# Altium schematic sheet IO-Communite.SchDoc(IO-Communite)
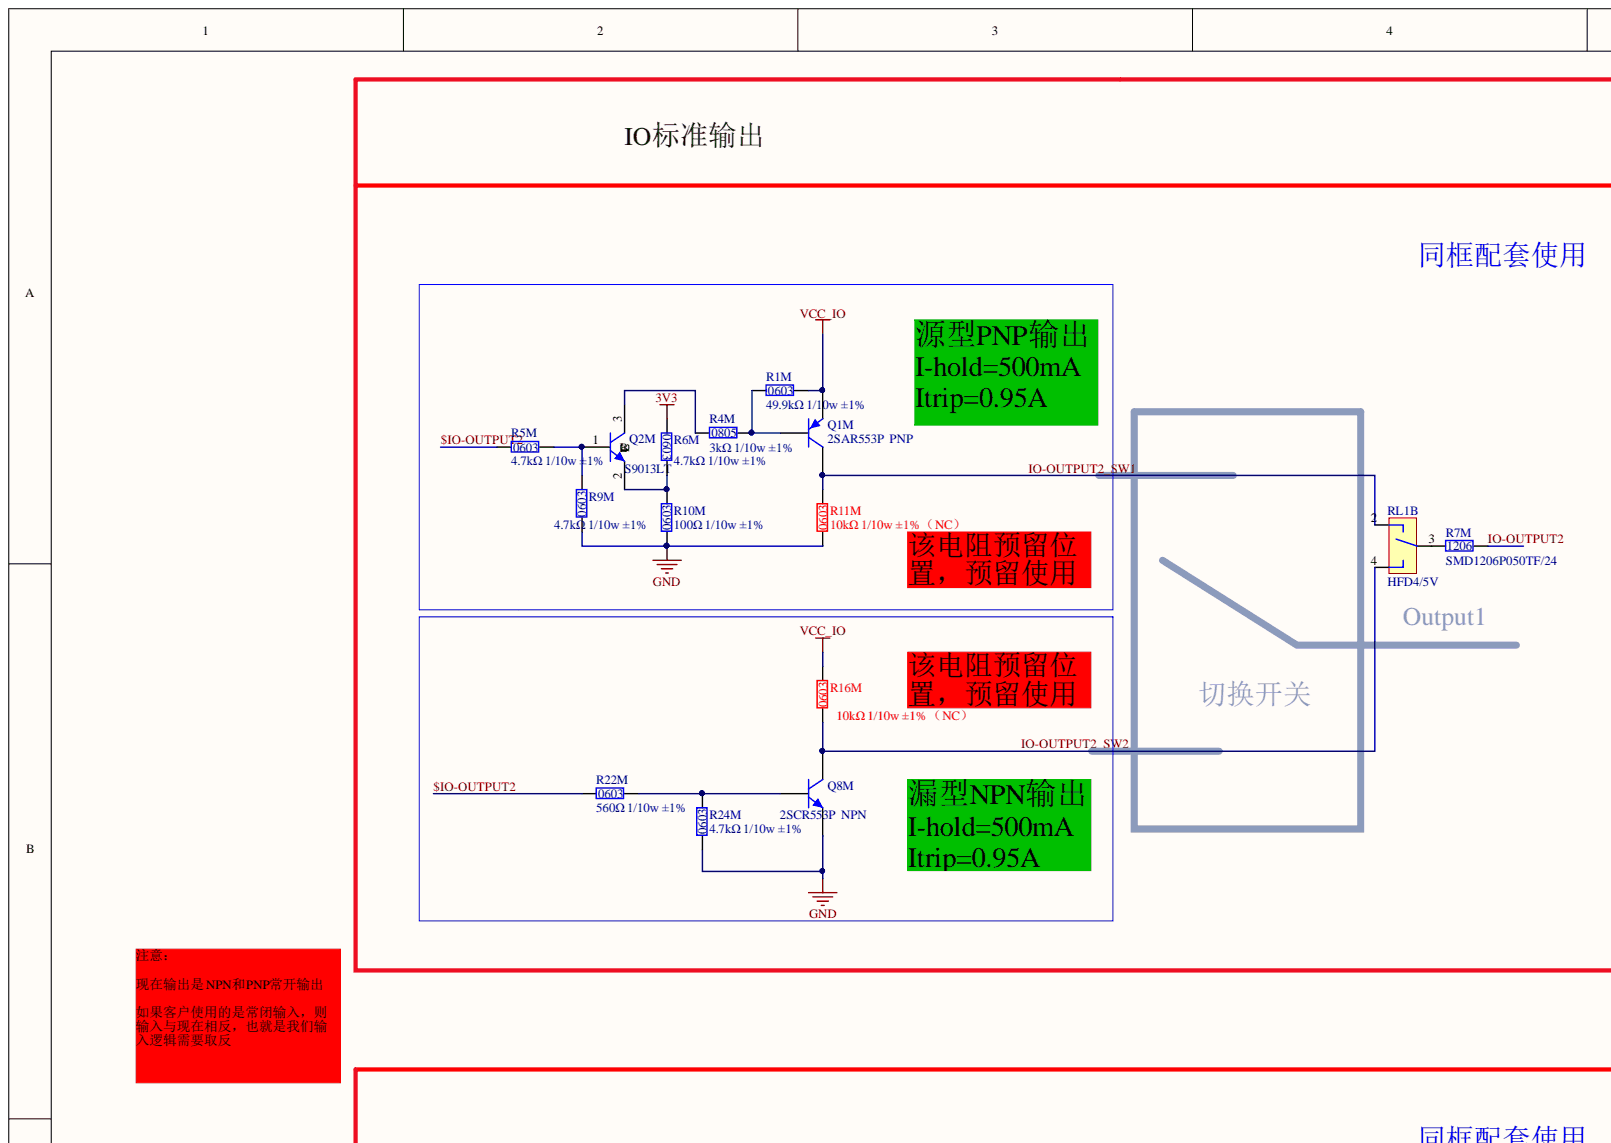
[diagram p0: IO-Communite.SchDoc, top left]
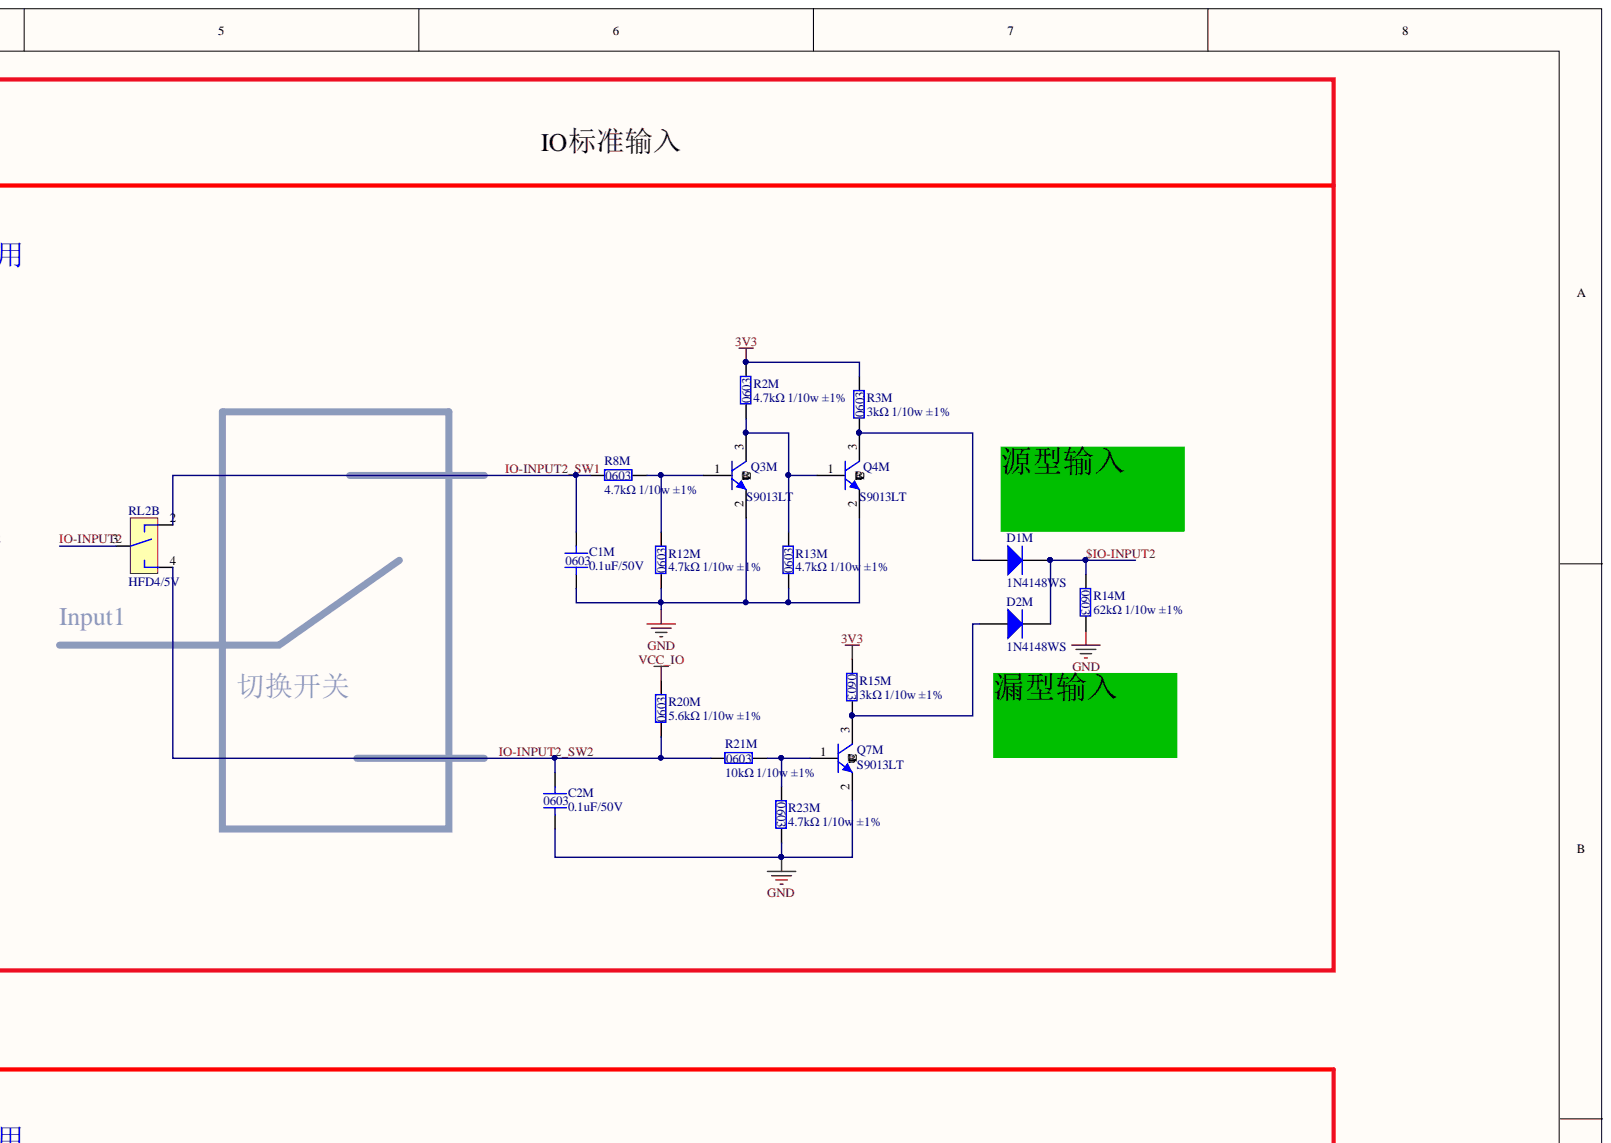
[diagram p1: IO-Communite.SchDoc, top right]
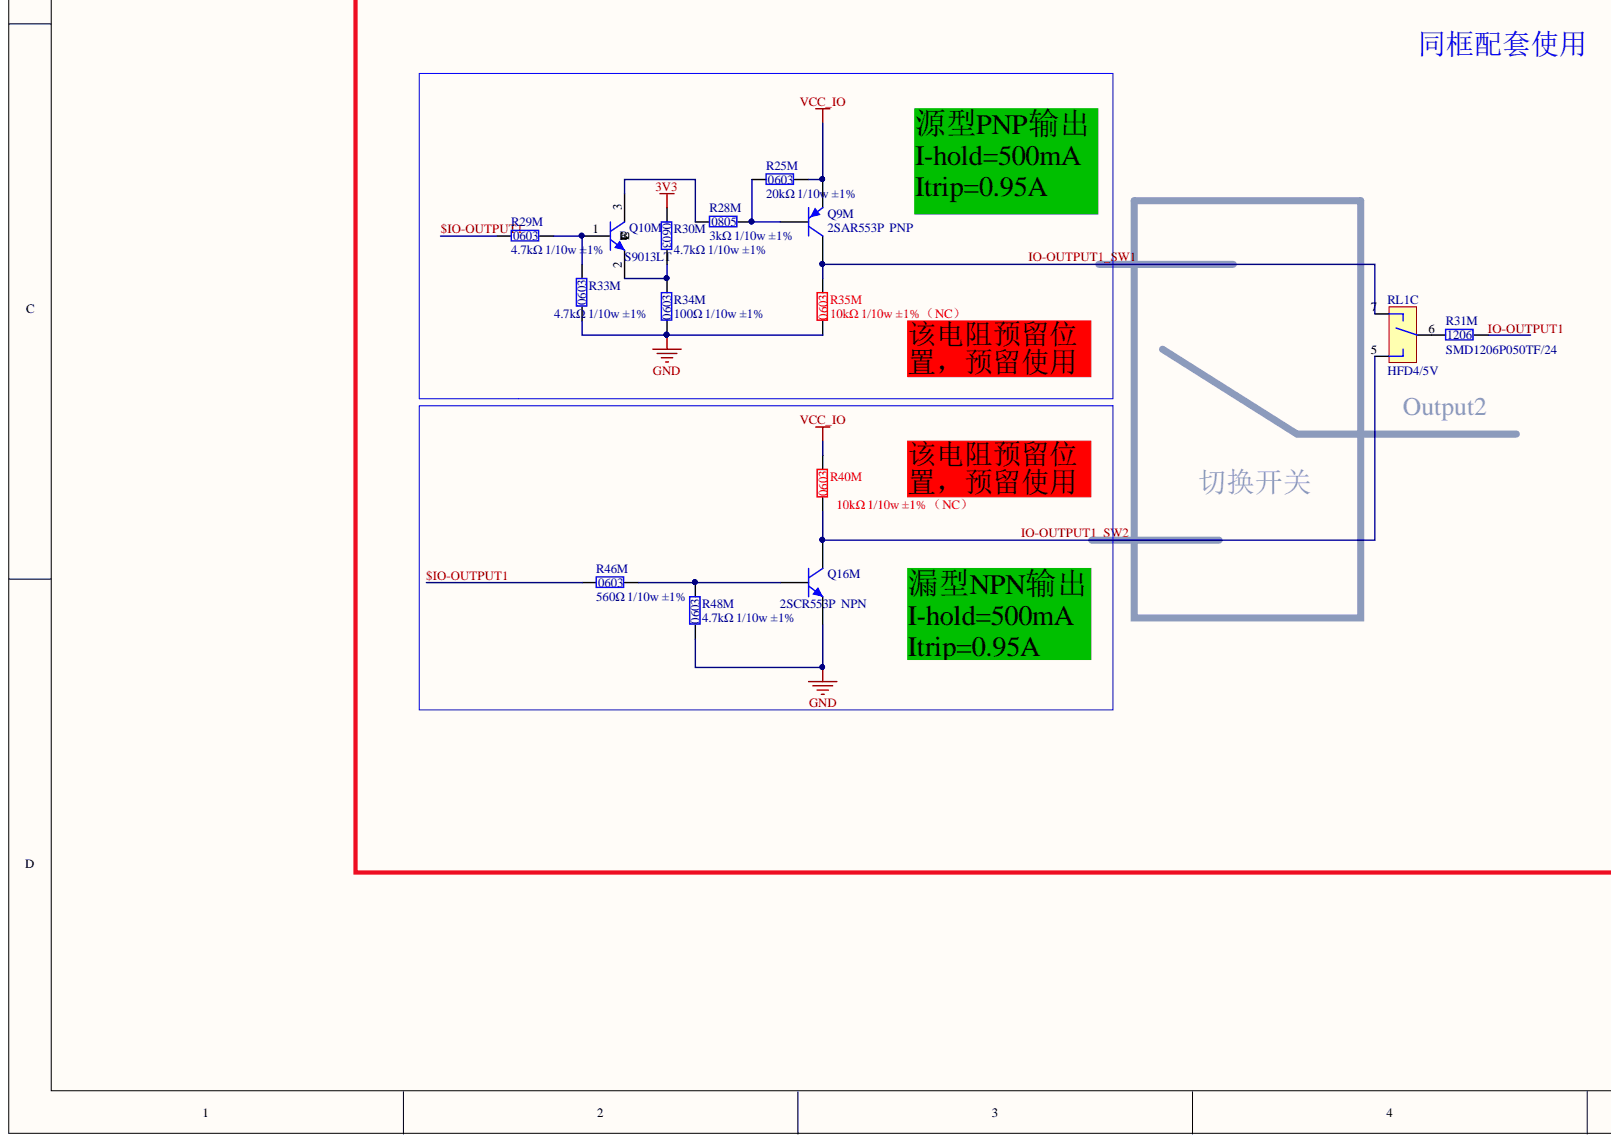
[diagram p2: IO-Communite.SchDoc, bottom left]
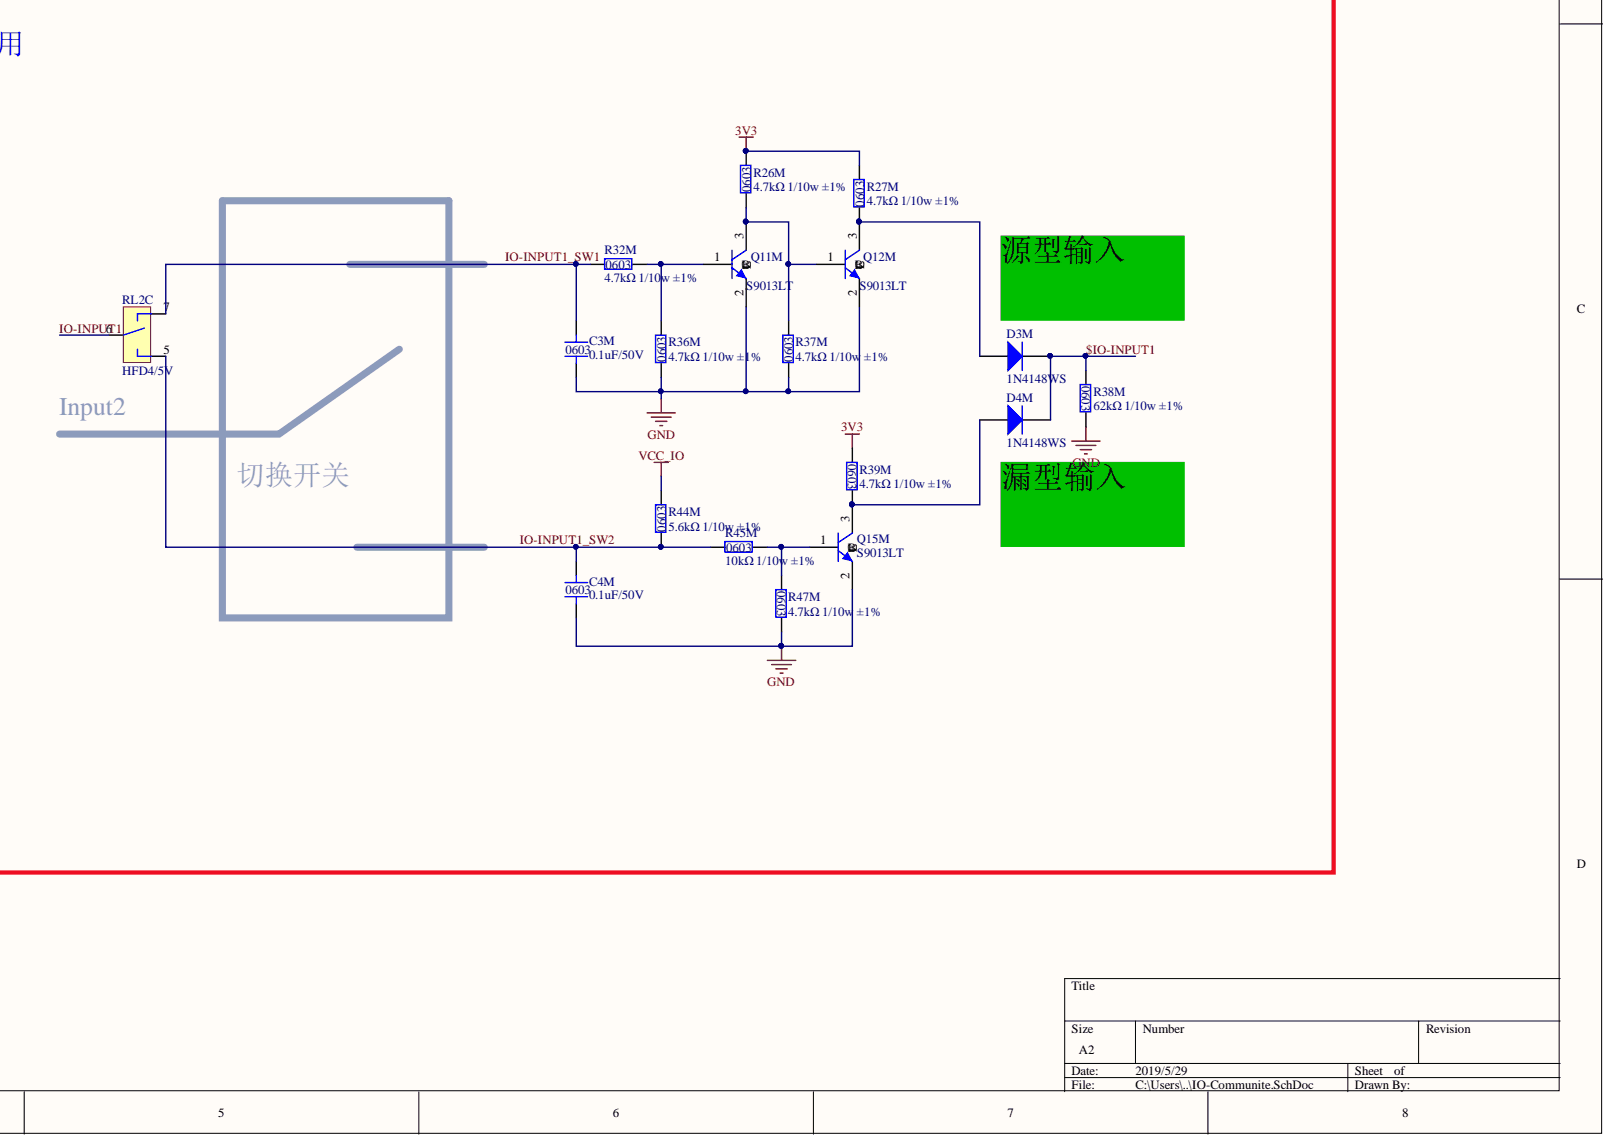
[diagram p3: IO-Communite.SchDoc, bottom right]

## Parts
C1M  0.1uF/50V  [C-0603]
C2M  0.1uF/50V  [C-0603]
C3M  0.1uF/50V  [C-0603]
C4M  0.1uF/50V  [C-0603]
D1M  1N4148WS  [SOD-323]
D2M  1N4148WS  [SOD-323]
D3M  1N4148WS  [SOD-323]
D4M  1N4148WS  [SOD-323]
Q1M  2SAR553P  PNP  [SOT-89]
Q2M  S9013LT  [SOT-23]
Q3M  S9013LT  [SOT-23]
Q4M  S9013LT  [SOT-23]
Q7M  S9013LT  [SOT-23]
Q8M  2SCR553P  NPN  [SOT-89]
Q9M  2SAR553P  PNP  [SOT-89]
Q10M  S9013LT  [SOT-23]
Q11M  S9013LT  [SOT-23]
Q12M  S9013LT  [SOT-23]
Q15M  S9013LT  [SOT-23]
Q16M  2SCR553P  NPN  [SOT-89]
R1M  49.9kΩ 1/10w ±1%  [R-0603]
R2M  4.7kΩ 1/10w ±1%  [R-0603]
R3M  3kΩ 1/10w ±1%  [R-0603]
R4M  3kΩ 1/10w ±1%  [R-0805]
R5M  4.7kΩ 1/10w ±1%  [R-0603]
R6M  4.7kΩ 1/10w ±1%  [R-0603]
R7M  SMD1206P050TF/24  [R-1206]
R8M  4.7kΩ 1/10w ±1%  [R-0603]
R9M  4.7kΩ 1/10w ±1%  [R-0603]
R10M  100Ω 1/10w ±1%  [R-0603]
R11M  10kΩ 1/10w ±1%（NC）  [R-0603]
R12M  4.7kΩ 1/10w ±1%  [R-0603]
R13M  4.7kΩ 1/10w ±1%  [R-0603]
R14M  62kΩ 1/10w ±1%  [R-0603]
R15M  3kΩ 1/10w ±1%  [R-0603]
R16M  10kΩ 1/10w ±1%（NC）  [R-0603]
R20M  5.6kΩ 1/10w ±1%  [R-0603]
R21M  10kΩ 1/10w ±1%  [R-0603]
R22M  560Ω 1/10w ±1%  [R-0603]
R23M  4.7kΩ 1/10w ±1%  [R-0603]
R24M  4.7kΩ 1/10w ±1%  [R-0603]
R25M  20kΩ 1/10w ±1%  [R-0603]
R26M  4.7kΩ 1/10w ±1%  [R-0603]
R27M  4.7kΩ 1/10w ±1%  [R-0603]
R28M  3kΩ 1/10w ±1%  [R-0805]
R29M  4.7kΩ 1/10w ±1%  [R-0603]
R30M  4.7kΩ 1/10w ±1%  [R-0603]
R31M  SMD1206P050TF/24  [R-1206]
R32M  4.7kΩ 1/10w ±1%  [R-0603]
R33M  4.7kΩ 1/10w ±1%  [R-0603]
R34M  100Ω 1/10w ±1%  [R-0603]
R35M  10kΩ 1/10w ±1%（NC）  [R-0603]
R36M  4.7kΩ 1/10w ±1%  [R-0603]
R37M  4.7kΩ 1/10w ±1%  [R-0603]
R38M  62kΩ 1/10w ±1%  [R-0603]
R39M  4.7kΩ 1/10w ±1%  [R-0603]
R40M  10kΩ 1/10w ±1%（NC）  [R-0603]
R44M  5.6kΩ 1/10w ±1%  [R-0603]
R45M  10kΩ 1/10w ±1%  [R-0603]
R46M  560Ω 1/10w ±1%  [R-0603]
R47M  4.7kΩ 1/10w ±1%  [R-0603]
R48M  4.7kΩ 1/10w ±1%  [R-0603]
RL1  HFD4/5V  [HFD4]  (parts B,C)
RL2  HFD4/5V  [HFD4]  (parts B,C)

## Nets
3V3: R2M.2 R3M.2 R6M.1 R15M.1 R26M.2 R27M.2 R30M.1 R39M.1
GND: C1M.1 C2M.1 C3M.1 C4M.1 Q3M.2 Q4M.2 Q7M.2 Q8M.2 Q11M.2 Q12M.2 Q15M.2 Q16M.2 R9M.1 R10M.1 R11M.1 R12M.1 R13M.1 R14M.2 R23M.2 R24M.1 R33M.1 R34M.1 R35M.1 R36M.1 R37M.1 R38M.2 R47M.2 R48M.1
VCC_IO: Q1M.2 Q9M.2 R1M.2 R16M.2 R20M.2 R25M.2 R40M.2 R44M.2
$IO-INPUT1: D3M.2 D4M.2 R38M.1
$IO-INPUT2: D1M.2 D2M.2 R14M.1
$IO-OUTPUT1: R29M.1 R46M.1
$IO-OUTPUT2: R5M.1 R22M.1
IO-INPUT1: RL2.6
IO-INPUT1_SW1: C3M.2 R32M.1 RL2.7
IO-INPUT1_SW2: C4M.2 R44M.1 R45M.1 RL2.5
IO-INPUT2: RL2.3
IO-INPUT2_SW1: C1M.2 R8M.1 RL2.2
IO-INPUT2_SW2: C2M.2 R20M.1 R21M.1 RL2.4
IO-OUTPUT1: R31M.2
IO-OUTPUT1_SW1: Q9M.3 R35M.2 RL1.7
IO-OUTPUT1_SW2: Q16M.3 R40M.1 RL1.5
IO-OUTPUT2: R7M.2
IO-OUTPUT2_SW1: Q1M.3 R11M.2 RL1.2
IO-OUTPUT2_SW2: Q8M.3 R16M.1 RL1.4
NetD1M_1: D1M.1 Q4M.3 R3M.1
NetD2M_1: D2M.1 Q7M.3 R15M.2
NetD3M_1: D3M.1 Q12M.3 R27M.1
NetD4M_1: D4M.1 Q15M.3 R39M.2
NetQ1M_1: Q1M.1 R1M.1 R4M.2
NetQ2M_1: Q2M.1 R5M.2 R9M.2
NetQ2M_2: Q2M.2 R6M.2 R10M.2
NetQ2M_3: Q2M.3 R4M.1
NetQ3M_1: Q3M.1 R8M.2 R12M.2
NetQ3M_3: Q3M.3 Q4M.1 R2M.1 R13M.2
NetQ7M_1: Q7M.1 R21M.2 R23M.1
NetQ8M_1: Q8M.1 R22M.2 R24M.2
NetQ9M_1: Q9M.1 R25M.1 R28M.2
NetQ10M_1: Q10M.1 R29M.2 R33M.2
NetQ10M_2: Q10M.2 R30M.2 R34M.2
NetQ10M_3: Q10M.3 R28M.1
NetQ11M_1: Q11M.1 R32M.2 R36M.2
NetQ11M_3: Q11M.3 Q12M.1 R26M.1 R37M.2
NetQ15M_1: Q15M.1 R45M.2 R47M.1
NetQ16M_1: Q16M.1 R46M.2 R48M.2
NetR7M_1: R7M.1 RL1.3
NetR31M_1: R31M.1 RL1.6

## Library data of the parts
C1M C2M C3M C4M = 10uF/50V: Component Kind: Standard; Library Name: dahuan.SchLib
D1M D2M D3M D4M = Diode 1N4148: High Conductance Fast Diode; Code_JEDEC: DO-204-AH; Component Kind: Standard; ComponentLink1Description: Manufacturer Link; ComponentLink1URL: http://www.fairchildsemi.com/; ComponentLink2Description: Datasheet; ComponentLink2URL: http://www.fairchildsemi.com/ds/1N%2F1N4148.pdf; DatasheetVersion: 1997; LatestRevisionDate: 17-Jul-2002; LatestRevisionNote: Re-released for DXP Platform.; Library Name: Miscellaneous Devices.IntLib; PackageDescription: Diode, Axial; Body 3.8 x 1.9 mm (LxDia. max), Lead Dia. 0.53 mm (max); PackageReference: DO-35; Published: 8-Jun-2000; Signal Integrity: 1N4148; Simulation: 1N4148; Publisher: Altium Limited
Q1M Q9M = PNP: Component Kind: Standard; Library Name: dahuan.SchLib
Q2M Q3M Q4M Q7M Q8M Q10M Q11M Q12M Q15M Q16M = NPN: Component Kind: Standard; Library Name: dahuan.SchLib
R1M R2M R3M R5M R6M R8M R9M R10M R11M R12M R13M R14M R15M R16M R20M R21M R22M R23M R24M R25M R26M R27M R29M R30M R32M R33M R34M R35M R36M R37M R38M R39M R40M R44M R45M R46M R47M R48M = 2kΩ 1/10w ±1%: Component Kind: Standard; Library Name: dahuan.SchLib
R7M R31M = 4kΩ 1/10w ±1%: Component Kind: Standard; Library Name: dahuan.IntLib
R4M R28M = 3kΩ 1/10w ±1%: Component Kind: Standard; Library Name: dahuan.SchLib
RL1 RL2 = HFD4/5V: Component Kind: Standard; Library Name: dahuan.SchLib
